# Altium schematic sheet [3]power_chips.SchDoc
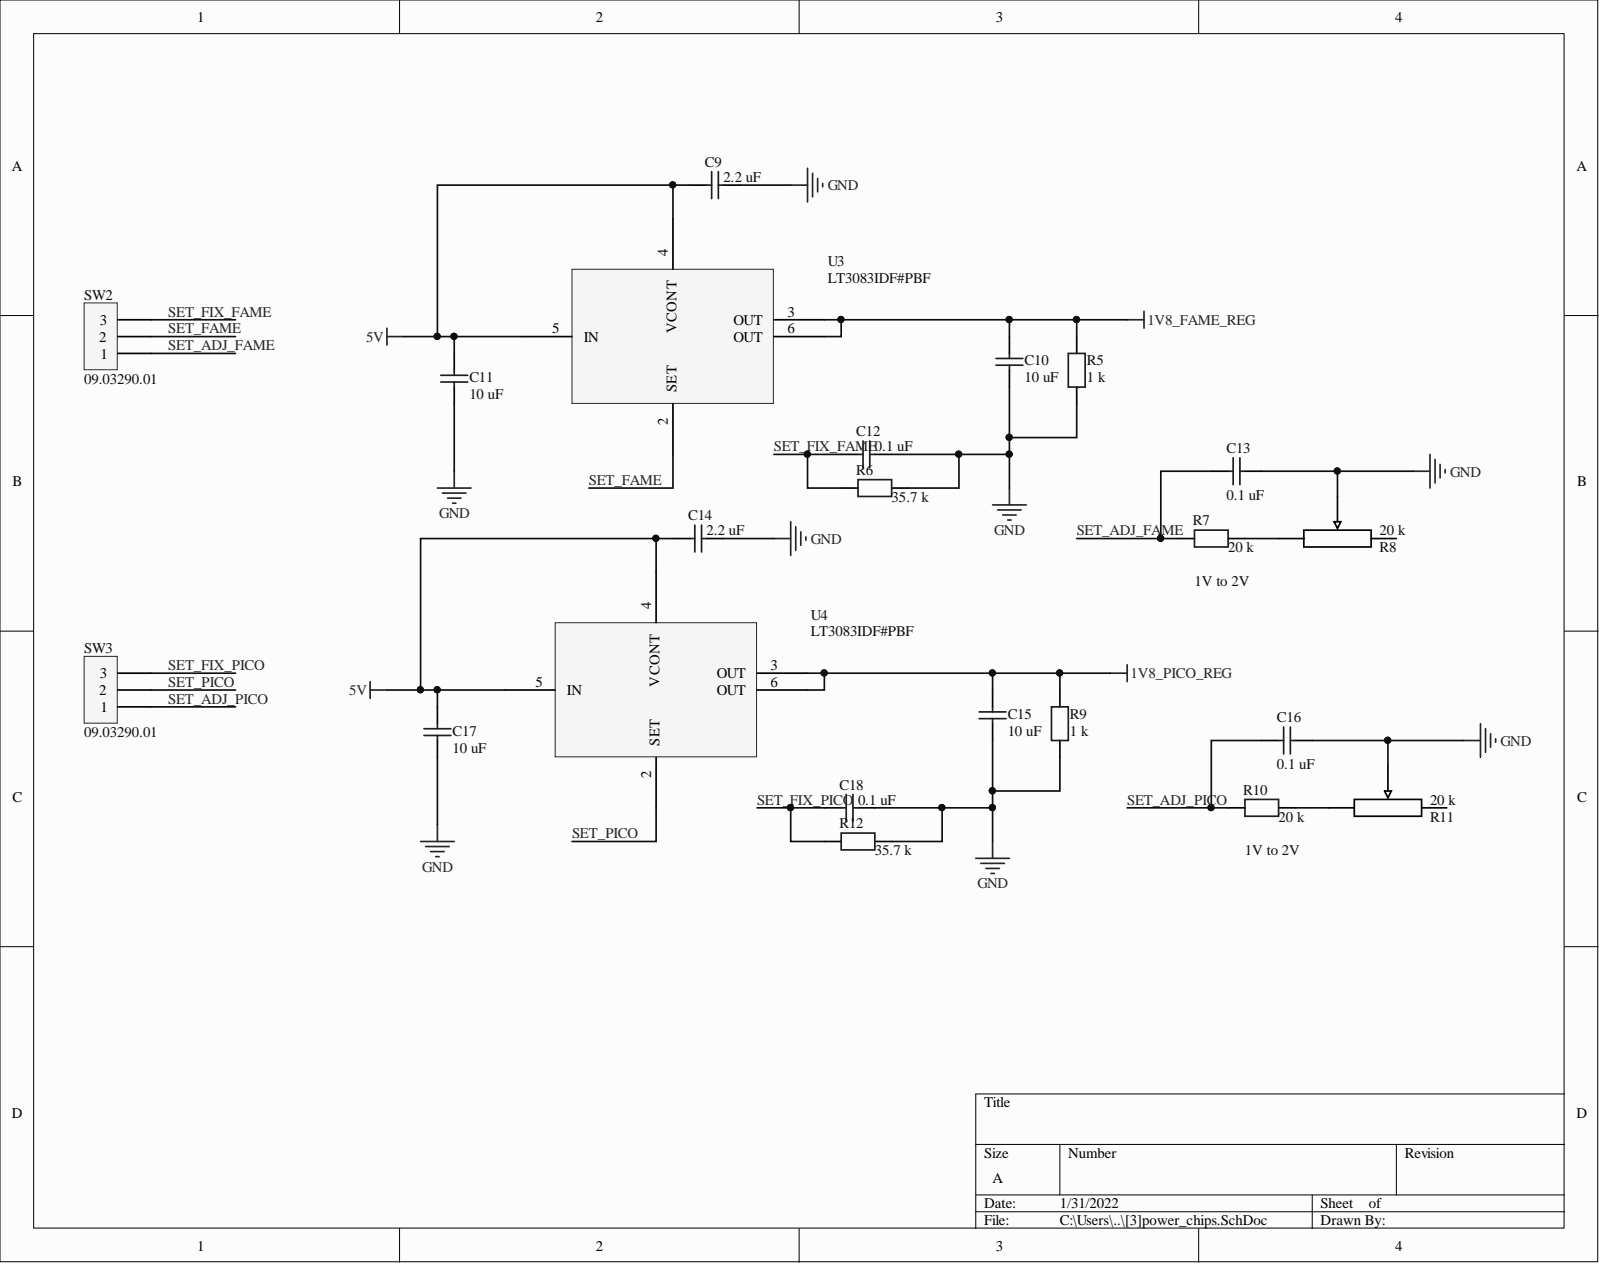
[diagram p0: [3]power_chips.SchDoc]

## Parts
C9  value 2.2 uF  [0402-CAP-ASM]  (hidden comment: Cap)
C10  value 10 uF  [0805-CAP-ASM]  (hidden comment: Cap)
C11  value 10 uF  [0805-CAP-ASM]  (hidden comment: Cap)
C12  value 0.1 uF  [0402-CAP-ASM]  (hidden comment: Cap)
C13  value 0.1 uF  [0402-CAP-ASM]  (hidden comment: Cap)
C14  value 2.2 uF  [0402-CAP-ASM]  (hidden comment: Cap)
C15  value 10 uF  [0805-CAP-ASM]  (hidden comment: Cap)
C16  value 0.1 uF  [0402-CAP-ASM]  (hidden comment: Cap)
C17  value 10 uF  [0805-CAP-ASM]  (hidden comment: Cap)
C18  value 0.1 uF  [0402-CAP-ASM]  (hidden comment: Cap)
R5  value 1 k  [0402-RES-ASM]  (hidden comment: res)
R6  value 35.7 k  [0402-RES-ASM]  (hidden comment: res)
R7  value 20 k  [0402-RES-ASM]  (hidden comment: res)
R8  value 20 k  [3362P-1-203LF]  (hidden comment: 3362P-1-203LF)
R9  value 1 k  [0402-RES-ASM]  (hidden comment: res)
R10  value 20 k  [0402-RES-ASM]  (hidden comment: res)
R11  value 20 k  [3362P-1-203LF]  (hidden comment: 3362P-1-203LF)
R12  value 35.7 k  [0402-RES-ASM]  (hidden comment: res)
SW2  09.03290.01  [09.03290.01]
SW3  09.03290.01  [09.03290.01]
U3  LT3083IDF#PBF  [DFN12-LT3083IDF#PBF]
U4  LT3083IDF#PBF  [DFN12-LT3083IDF#PBF]

## Nets
1V8_FAME_REG: C10.2 R5.2 U3.3(OUT) U3.6(OUT)
1V8_PICO_REG: C15.2 R9.2 U4.3(OUT) U4.6(OUT)
5V: C9.1 C11.2 C14.1 C17.2 U3.4(VCONT) U3.5(IN) U4.4(VCONT) U4.5(IN)
GND: C9.2 C10.1 C11.1 C12.2 C13.1 C14.2 C15.1 C16.1 C17.1 C18.2 R5.1 R6.2 R8.2 R9.1 R11.2 R12.2
SET_ADJ_FAME: C13.2 R7.1 SW2.1
SET_ADJ_PICO: C16.2 R10.1 SW3.1
SET_FAME: SW2.2 U3.2(SET)
SET_FIX_FAME: C12.1 R6.1 SW2.3
SET_FIX_PICO: C18.1 R12.1 SW3.3
SET_PICO: SW3.2 U4.2(SET)
NetR7_2: R7.2 R8.1
NetR10_2: R10.2 R11.1

Not connected: R8 3; R11 3

## Library data of the parts
C9 C14 = Cap: CAP CER 2.2UF 16V X5R 0402; Component Kind: Standard; Library Name: Miscellaneous Devices.IntLib; Supplier Part Number: 490-10456-1-ND
C10 C11 C15 C17 = Cap: CAP CER 10UF 25V JB 0805; Component Kind: Standard; Library Name: Miscellaneous Devices.IntLib; Supplier Part Number: 445-11463-1-ND
C12 C13 C16 C18 = Cap: CAP CER 0.1UF 10V X5R 0402; Component Kind: Standard; Library Name: Miscellaneous Devices.IntLib; Supplier Part Number: 587-1227-1-ND
R5 R9 = RESISTOR: RES SMD 1K OHM 1% 1/16W 0402; Component Kind: Standard; Library Name: Mylib..SchLib; Supplier Part Number: YAG1234CT-ND
R6 R12 = RESISTOR: RES SMD 35.7KOHM 0.1% 1/16W 0402; Component Kind: Standard; Library Name: Mylib..SchLib; Supplier Part Number: A102810CT-ND
R7 R10 = RESISTOR: RES SMD 20K OHM 1% 1/16W 0402; Component Kind: Standard; Library Name: Mylib..SchLib; Supplier Part Number: 311-20.0KLRCT-ND
R8 R11 = 3362P-1-203LF: TRIMMER 20K OHM 0.5W PC PIN TOP; Component Kind: Standard; Library Name: Mylib..SchLib; Supplier Part Number: 3362P-203LF-ND
SW2 SW3 = 09.03290.01: 1K2 SW CHANGE OVER RAISED ACT; Component Kind: Standard; Library Name: Mylib..SchLib; Supplier Part Number: 1948-1087-ND
U3 U4 = LT3083IDF#PBF: IC REG LINEAR POS ADJ 3A 12DFN; Component Kind: Standard; Library Name: Mylib..SchLib; Supplier Part Number: LT3083IDF#PBF-ND
